# Altium schematic sheet Fonte 5V5 8A.SchDoc
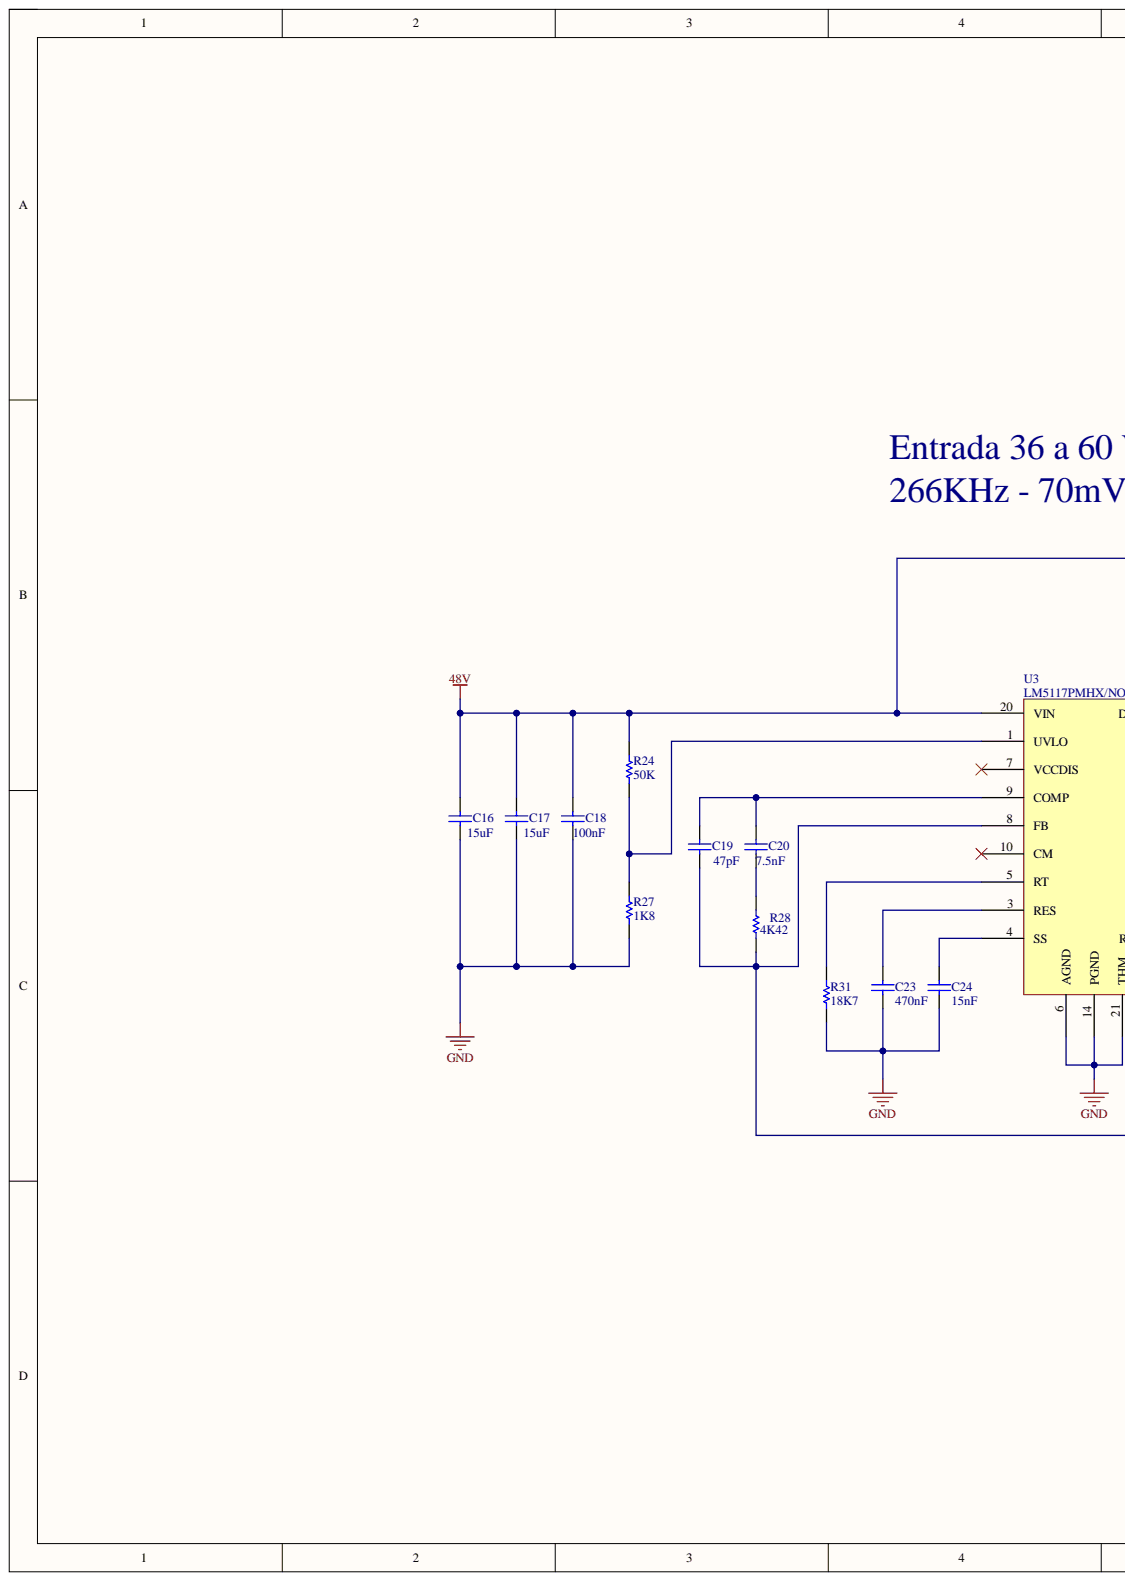
[diagram p0: Fonte 5V5 8A.SchDoc, left]
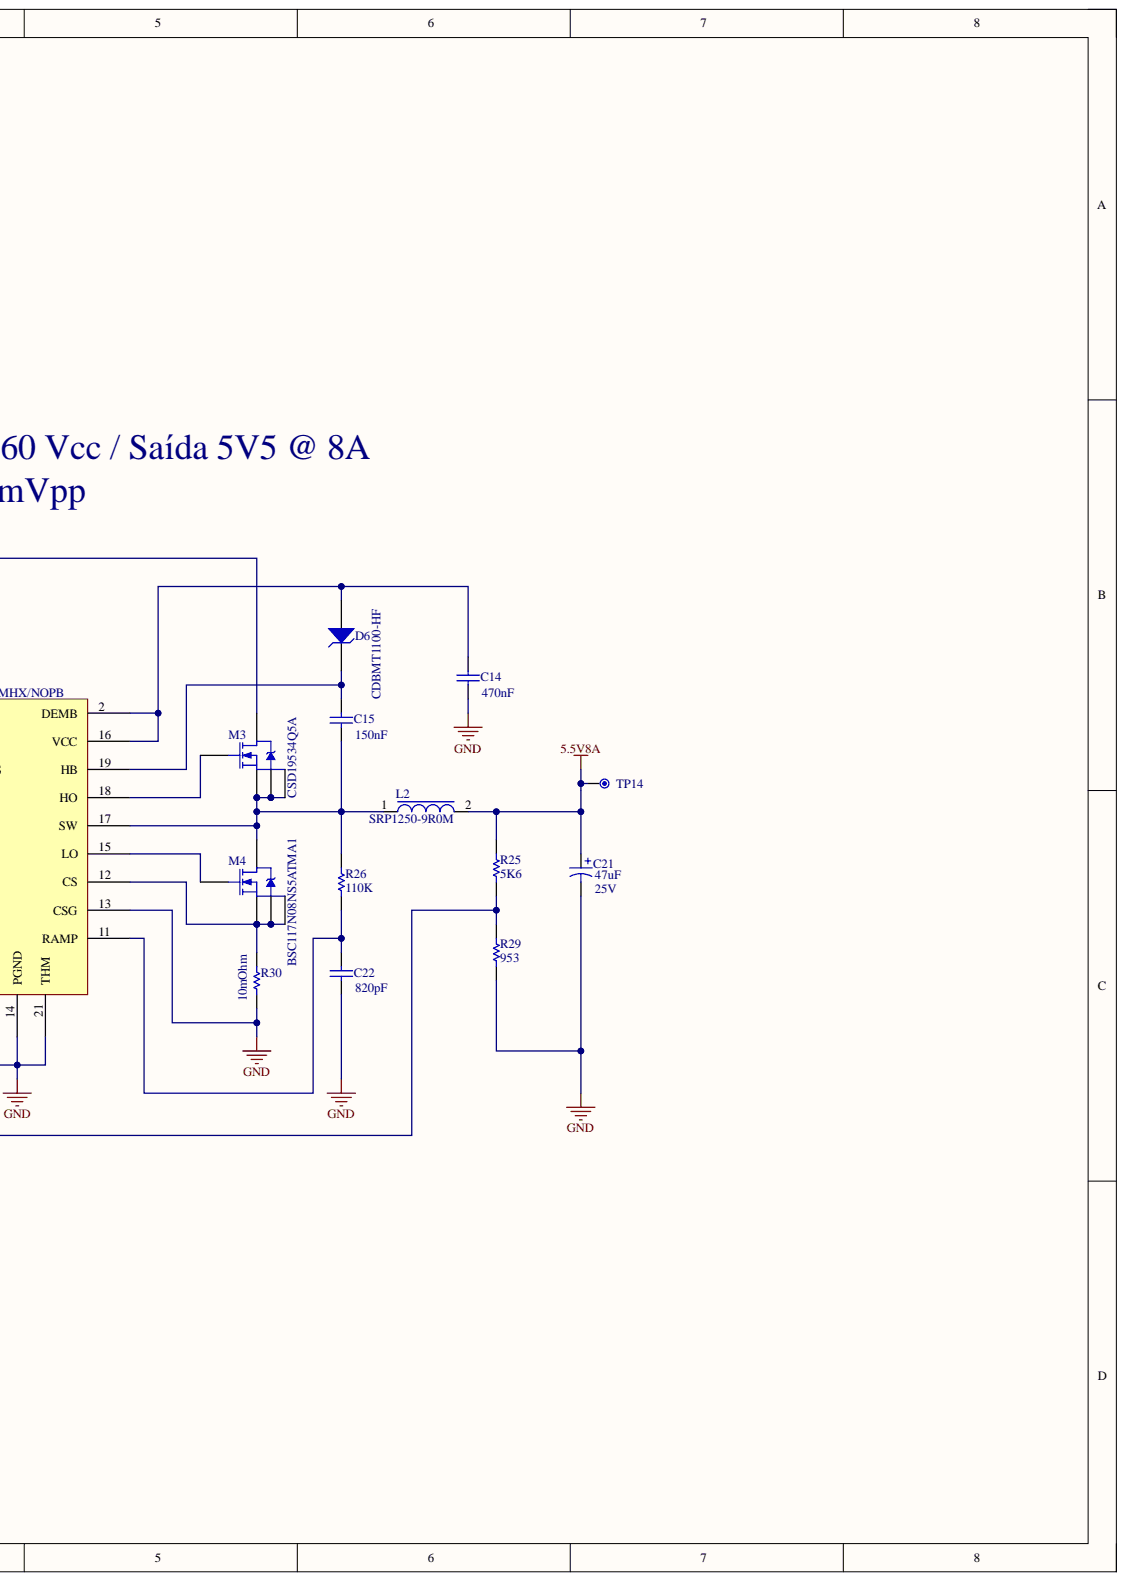
[diagram p1: Fonte 5V5 8A.SchDoc, right]

## Parts
C14  GRM188R71A474KA61D: 470nF  [SMD_0603]  (hidden comment: GRM188R71A474KA61D)
C15  GRM188R71A154KA01D: 150nF  [SMD_0603]  (hidden comment: GRM188R71A154KA01D)
C16  C5750X7S2A156M250KB: 15uF  [5750]  (hidden comment: C5750X7S2A156M250KB)
C17  C5750X7S2A156M250KB: 15uF  [5750]  (hidden comment: C5750X7S2A156M250KB)
C18  C1608X7S2A104K080AE: 100nF  [SMD_0603]  (hidden comment: C1608X7S2A104K080AE)
C19  GRM1885C1H470JA01D: 47pF  [SMD_0603]  (hidden comment: GRM1885C1H470JA01D)
C20  C0603C752K4HACAUTO: 7.5nF  [SMD_0603]  (hidden comment: C0603C752K4HACAUTO)
C21  25SVPF47M: 47uF  Voltage: 25V  [Cap Aluminio 6,3 com furo]  (hidden comment: 25SVPF47M)
C22  C0603C821J5GACTU: 820pF  [SMD_0603]  (hidden comment: C0603C821J5GACTU)
C23  GRM188R71C474KA88D: 470nF  [SMD_0603]  (hidden comment: GRM188R71C474KA88D)
C24  CGA4F2C0G1H153J085AA: 15nF  [SMD_0603]  (hidden comment: CGA4F2C0G1H153J085AA)
D6  CDBMT1100-HF  [SMD_SOD123-3]
L2  SRP1250-9R0M  [SRP1250-3R3M]
M3  CSD19534Q5A  [TDSON_8]
M4  BSC117N08NS5ATMA1  [TDSON_8]
R24  RT0603BRD0750KL: 50K  [SMD_0603]  (hidden comment: RT0603BRD0750KL)
R25  RC0603FR-075K6L: 5K6  [SMD_0603]  (hidden comment: RC0603FR-075K6L)
R26  RC0603FR-07110KL: 110K  [SMD_0603]  (hidden comment: RC0603FR-07110KL)
R27  RC0603JR-071K8L: 1K8  [SMD_0603]  (hidden comment: RC0603JR-071K8L)
R28  RC0603FR-074K42L: 4K42  [SMD_0603]  (hidden comment: RC0603FR-074K42L)
R29  RC0603FR-07953RL  [SMD_0603]  (value not drawn)
R30  ERJ-8CWFR010V: 10mOhm  [SMD_1206]  (hidden comment: ERJ-8CWFR010V)
R31  RMCF0603FT18K7: 18K7  [SMD_0603]  (hidden comment: RMCF0603FT18K7)
TP14  TP-1MM  [TESTPOINT-1MM]  (value not drawn)  (no BOM)
U3  LM5117PMHX/NOPB  [HTSSOP-20 (PWP0020A)]

## Nets
5.5V8A: C21.1 L2.2 R25.1 TP14.1
48V: C16.2 C17.2 C18.2 M3.5 R24.2 U3.20(VIN)
GND: C14.1 C16.1 C17.1 C18.1 C21.2 C22.1 C23.1 C24.2 R27.1 R29.2 R30.1 R31.1 U3.6(AGND) U3.13(CSG) U3.14(PGND) U3.21(THM)
NetC14_2: C14.2 D6.1 U3.2(DEMB) U3.16(VCC)
NetC15_1: C15.1 L2.1 M3.1 M3.2 M3.3 M4.5 R26.2 U3.17(SW)
NetC15_2: C15.2 D6.2 U3.19(HB)
NetC19_1: C19.1 R25.2 R28.1 R29.1 U3.8(FB)
NetC19_2: C19.2 C20.2 U3.9(COMP)
NetC20_1: C20.1 R28.2
NetC22_2: C22.2 R26.1 U3.11(RAMP)
NetC23_2: C23.2 U3.3(RES)
NetC24_1: C24.1 U3.4(SS)
NetM3_4: M3.4 U3.18(HO)
NetM4_1: M4.1 M4.2 M4.3 R30.2 U3.12(CS)
NetM4_4: M4.4 U3.15(LO)
NetR24_1: R24.1 R27.2 U3.1(UVLO)
NetR31_2: R31.2 U3.5(RT)

Not connected: U3 7(VCCDIS) 10(CM)

## Library data of the parts
C14-C18 C22-C24 = Cap Semi: Capacitor (Semiconductor SIM Model); Component Kind: Standard; Library Name: Miscellaneous Devices.IntLib; PackageReference: C1206; Published: 8-Jun-2000; Signal Integrity: Cap Semi; Simulation: CAP; Publisher: Altium Limited
  C14 C15 C22-C24: LatestRevisionDate: 17-Jul-2002; LatestRevisionNote: Re-released for DXP Platform.
  C16-C18: LatestRevisionDate: 29-May-2009; LatestRevisionNote: IPC-7351 Footprint Added.; PackageDescription: Chip Capacitor
C19 = Cap Semi: Capacitor (Semiconductor SIM Model); Part Number: GCM1885C1H150JA16D; Component Kind: Standard; LatestRevisionDate: 17-Jul-2002; LatestRevisionNote: Re-released for DXP Platform.; Library Name: Miscellaneous Devices.IntLib; PackageReference: C1206; Published: 8-Jun-2000; Signal Integrity: Cap Semi; Simulation: CAP; Publisher: Altium Limited
C20 = Cap Semi: Capacitor (Semiconductor SIM Model); Part Number: CL10C332JB8NFNC; Component Kind: Standard; LatestRevisionDate: 17-Jul-2002; LatestRevisionNote: Re-released for DXP Platform.; Library Name: Miscellaneous Devices.IntLib; PackageReference: C1206; Published: 8-Jun-2000; Signal Integrity: Cap Semi; Simulation: CAP; Publisher: Altium Limited
C21 = Cap Pol1: Polarized Capacitor (Radial); Component Kind: Standard; LatestRevisionDate: 17-Jul-2002; LatestRevisionNote: Re-released for DXP Platform.; Library Name: Miscellaneous Devices.IntLib; PackageReference: RB7.6-15; Published: 8-Jun-2000; Signal Integrity: Cap Pol; Simulation: CAP; Publisher: Altium Limited
D6 = BAS316-TP: DIODE 100V 500MA SOD323; Component Kind: Standard; Supplier 1: Digi-Key; Supplier Part Number 1: BAS316-TPMSCT-ND; Library Name: XM_Diodes.SchLib
L2 = 74435588200: FIXED IND 82UH 7A 30.4 MOHM SMD; Component Kind: Standard; Supplier 1: Digi-Key; Supplier Part Number 1: 732-4241-1-ND; Library Name: XM_Inductor.SchLib
M3 M4 = BSC117N08NS5ATMA1: MOSFET N-CH 80V 49A Automotive; Component Kind: Standard; Library Name: XM_Fet.SchLib
R24 R25 R27 R31 = Res Semi: Semiconductor Resistor; Component Kind: Standard; LatestRevisionDate: 17-Jul-2002; LatestRevisionNote: Re-released for DXP Platform.; Library Name: Miscellaneous Devices.IntLib; PackageReference: AXIAL-0.5; Published: 8-Jun-2000; Signal Integrity: Res Semi; Simulation: RES; Publisher: Altium Limited
R26 = Res Semi: Semiconductor Resistor; Part Number: CRCW120628K0FKEA; Component Kind: Standard; LatestRevisionDate: 17-Jul-2002; LatestRevisionNote: Re-released for DXP Platform.; Library Name: Miscellaneous Devices.IntLib; PackageReference: AXIAL-0.5; Published: 8-Jun-2000; Signal Integrity: Res Semi; Simulation: RES; Publisher: Altium Limited
R28 = Res Semi: Semiconductor Resistor; Part Number: RC0603FR-0710K2L; Component Kind: Standard; LatestRevisionDate: 17-Jul-2002; LatestRevisionNote: Re-released for DXP Platform.; Library Name: Miscellaneous Devices.IntLib; PackageReference: AXIAL-0.5; Published: 8-Jun-2000; Signal Integrity: Res Semi; Simulation: RES; Publisher: Altium Limited
R29 = Res Semi: Semiconductor Resistor; RC0603FR-07953RL: 953; Component Kind: Standard; LatestRevisionDate: 17-Jul-2002; LatestRevisionNote: Re-released for DXP Platform.; Library Name: Miscellaneous Devices.IntLib; PackageReference: AXIAL-0.5; Published: 8-Jun-2000; Signal Integrity: Res Semi; Simulation: RES; Publisher: Altium Limited
R30 = Res Semi: Semiconductor Resistor; Part Number: WSLP12068L000FEA; Component Kind: Standard; LatestRevisionDate: 17-Jul-2002; LatestRevisionNote: Re-released for DXP Platform.; Library Name: Miscellaneous Devices.IntLib; PackageReference: AXIAL-0.5; Published: 8-Jun-2000; Signal Integrity: Res Semi; Simulation: RES; Publisher: Altium Limited
U3 = LM5117PMHX/NOPB: Component Kind: Standard; Library Name: XM_IC.SchLib
TP14 = TP-1MM: Library Name: xmobots_-_comp_library.IntLib
